# Altium schematic sheet eGAN-FET-module_Connectors.SchDoc
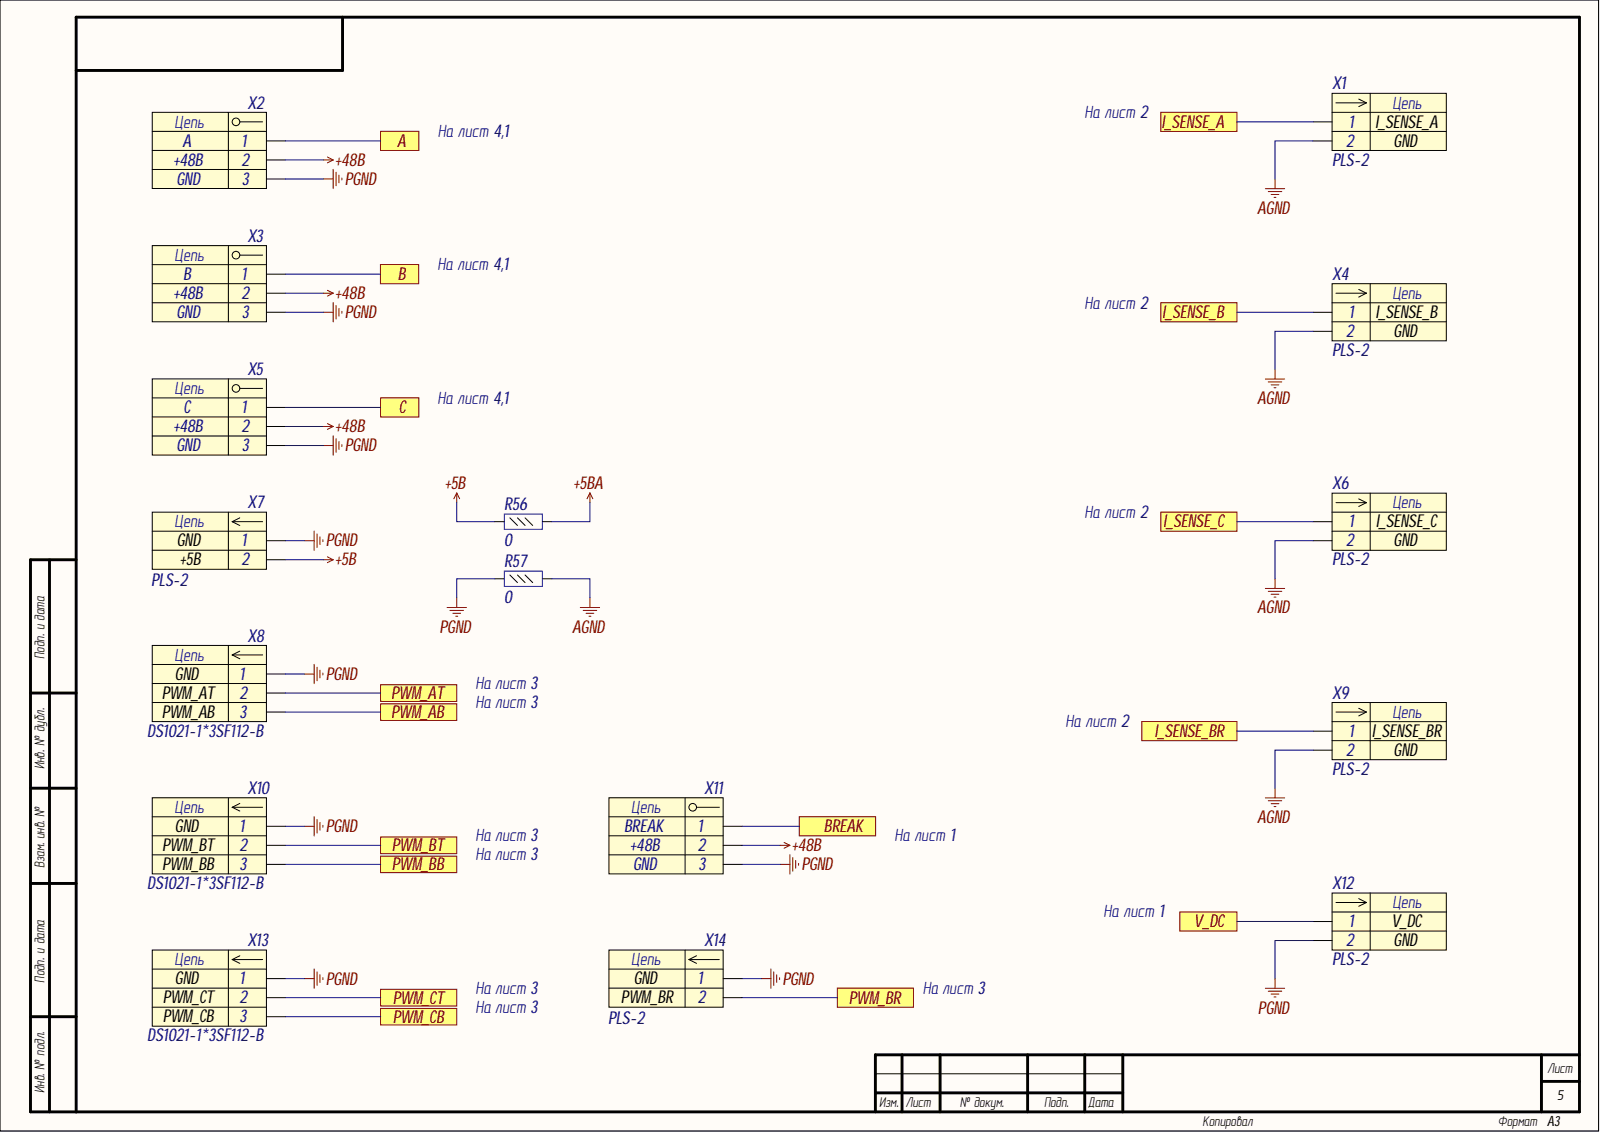
[diagram p0: eGAN-FET-module_Connectors.SchDoc]

## Parts
R56  0  [R0402]
R57  0  [R0402]
X1  Contact_1: I_SENSE_A  Contact_2: GND  [PLS-2]  (hidden comment: ')
X2  Contact_1: A  Contact_2: +48B  Contact_3: GND  [KUBO_3]  (hidden comment: ')
X3  Contact_1: B  Contact_2: +48B  Contact_3: GND  [KUBO_3]  (hidden comment: ')
X4  Contact_1: I_SENSE_B  Contact_2: GND  [PLS-2]  (hidden comment: ')
X5  Contact_1: C  Contact_2: +48B  Contact_3: GND  [KUBO_3]  (hidden comment: ')
X6  Contact_1: I_SENSE_C  Contact_2: GND  [PLS-2]  (hidden comment: ')
X7  Contact_1: GND  Contact_2: +5B  [PLS-2]  (hidden comment: ')
X8  DS1021-1*3SF112-B  Contact_1: GND  Contact_2: PWM_AT  Contact_3: PWM_AB  [PLS-3]
X9  Contact_1: I_SENSE_BR  Contact_2: GND  [PLS-2]  (hidden comment: ')
X10  DS1021-1*3SF112-B  Contact_1: GND  Contact_2: PWM_BT  Contact_3: PWM_BB  [PLS-3]
X11  Contact_1: BREAK  Contact_2: +48B  Contact_3: GND  [KUBO_3]  (hidden comment: ')
X12  Contact_1: V_DC  Contact_2: GND  [PLS-2]  (hidden comment: ')
X13  DS1021-1*3SF112-B  Contact_1: GND  Contact_2: PWM_CT  Contact_3: PWM_CB  [PLS-3]
X14  Contact_1: GND  Contact_2: PWM_BR  [PLS-2]  (hidden comment: ')

## Ports
A, B, BREAK, C, I_SENSE_A, I_SENSE_B, I_SENSE_BR, I_SENSE_C, PWM_AB, PWM_AT, PWM_BB, PWM_BR, PWM_BT, PWM_CB, PWM_CT, V_DC

## Nets
+5B: R56.1 X7.2
+5BA: R56.2
+48B: X2.2 X3.2 X5.2 X11.2
AGND: R57.2 X1.2 X4.2 X6.2 X9.2
PGND: R57.1 X2.3 X3.3 X5.3 X7.1 X8.1 X10.1 X11.3 X12.2 X13.1 X14.1
A: X2.1 ; port A
B: X3.1 ; port B
BREAK: X11.1 ; port BREAK
C: X5.1 ; port C
I_SENSE_A: X1.1 ; port I_SENSE_A
I_SENSE_B: X4.1 ; port I_SENSE_B
I_SENSE_BR: X9.1 ; port I_SENSE_BR
I_SENSE_C: X6.1 ; port I_SENSE_C
PWM_AB: X8.3 ; port PWM_AB
PWM_AT: X8.2 ; port PWM_AT
PWM_BB: X10.3 ; port PWM_BB
PWM_BR: X14.2 ; port PWM_BR
PWM_BT: X10.2 ; port PWM_BT
PWM_CB: X13.3 ; port PWM_CB
PWM_CT: X13.2 ; port PWM_CT
V_DC: X12.1 ; port V_DC

## Library data of the parts
R56 R57 = res197: Резистор; Manufacture: Yageo; Part Name: Resistor; Part Number: RC0402FR-070RL; Accuracy: 0.01; Database Table Name: Resistor$; Power: 0,062Вт; Component Kind: Standard; id: res197; Library Name: MOP44.DbLib; Value: 0
X1 X4 X6 X7 X9 X12 X14 = connect159: Разъем - 2 конт. вилка; Database Table Name: Connector$; Etch: 2,54mm; Component Kind: Standard; id: connect159; Library Name: MOP44.DbLib; Part Name: PLS-2
X2 X3 X5 X11 = connect234: Разъем - 3 конт. клеммник; Part Name: 3 отверстия под запайку; Database Table Name: Connector$; Component Kind: Standard; id: connect234; Library Name: MOP44.DbLib
X8 X10 X13 = connect33: Разъем - 3 конт. вилка; Manufacture: Ningbo connfly electronic; Database Table Name: Connector$; Etch: 2,54mm; Component Kind: Standard; id: connect33; Library Name: MOP44.DbLib; Part Name: PLS-3; Part Number: DS1021-1*3SF112-B
